# Altium schematic sheet joint_board_boosterpack.SchDoc
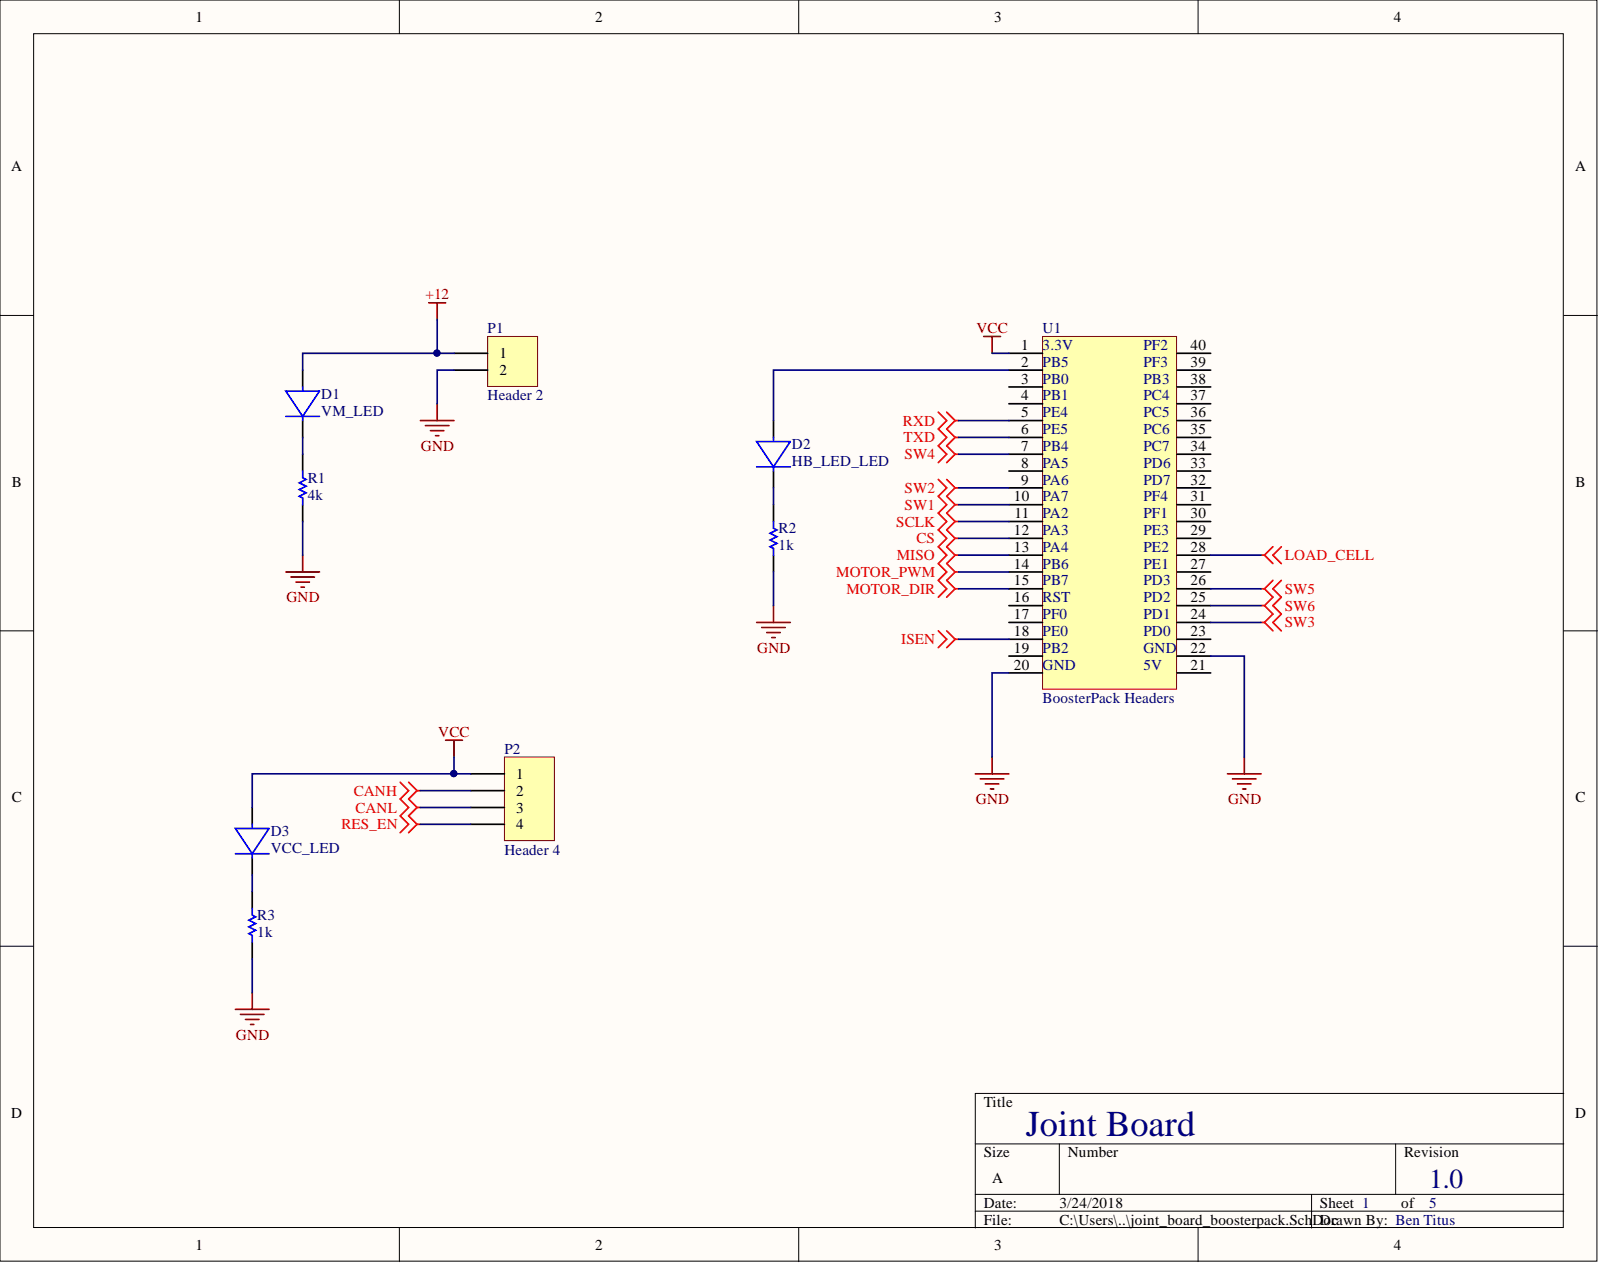
[diagram p0: joint_board_boosterpack.SchDoc]

## Parts
D1  VM_LED  [0805 Diode]
D2  HB_LED_LED  [0805 Diode]
D3  VCC_LED  [0805 Diode]
P1  Header 2  [HDR1X2]
P2  Header 4  [HDR1X4]
R1  4k  [RESC0805(2012)_L]
R2  1k  [RESC0805(2012)_L]
R3  1k  [RESC0805(2012)_L]
U1  BoosterPack Headers  [boosterpack_headers]

## Nets
+12: D1.1 P1.1
CANH: P2.2
CANL: P2.3
CS: U1.12
GND: P1.2 R1.1 R2.1 R3.1 U1.20 U1.22
ISEN: U1.18
LOAD_CELL: U1.28
MISO: U1.13
MOTOR_DIR: U1.15
MOTOR_PWM: U1.14(PB6)
RES_EN: P2.4
RXD: U1.5
SCLK: U1.11
SW1: U1.10
SW2: U1.9(PA6)
SW3: U1.24
SW4: U1.7
SW5: U1.26
SW6: U1.25
TXD: U1.6
VCC: D3.1 P2.1 U1.1
NetD1_2: D1.2 R1.2
NetD2_1: D2.1 U1.2
NetD2_2: D2.2 R2.2
NetD3_2: D3.2 R3.2

Not connected: U1 3 4(PB1) 8 16 17 19 21 23 27(PE1) 29 30 31 32(PD7) 33 34 35 36 37(PC4) 38 39 40

## Library data of the parts
D1-D3 = SMD_LED: Component Kind: Standard; Library Name: Custom_Lib.IntLib
P1 = Header 2: Header, 2-Pin; Component Kind: Standard; LatestRevisionDate: 17-Jul-2002; LatestRevisionNote: Re-released for DXP Platform.; Library Name: Miscellaneous Connectors.IntLib; Signal Integrity: Connector; Publisher: Altium Limited
P2 = Header 4: Header, 4-Pin; Component Kind: Standard; LatestRevisionDate: 17-Jul-2002; LatestRevisionNote: Re-released for DXP Platform.; Library Name: Miscellaneous Connectors.IntLib; Signal Integrity: Connector; Publisher: Altium Limited
R1-R3 = CMP-1591-00002-1: 0805 (2012 Metric) Chip Resistor; Case-EIA: 0805; Case-Metric: 2012; Component Kind: Standard; Library Name: Altium Content Vault; PackageReference: RESC0805(2012)
U1 = BoosterPack Headers: Component Kind: Standard; Library Name: boosterpack_pins.SchLib
